# Altium schematic sheet power_board.SchDoc
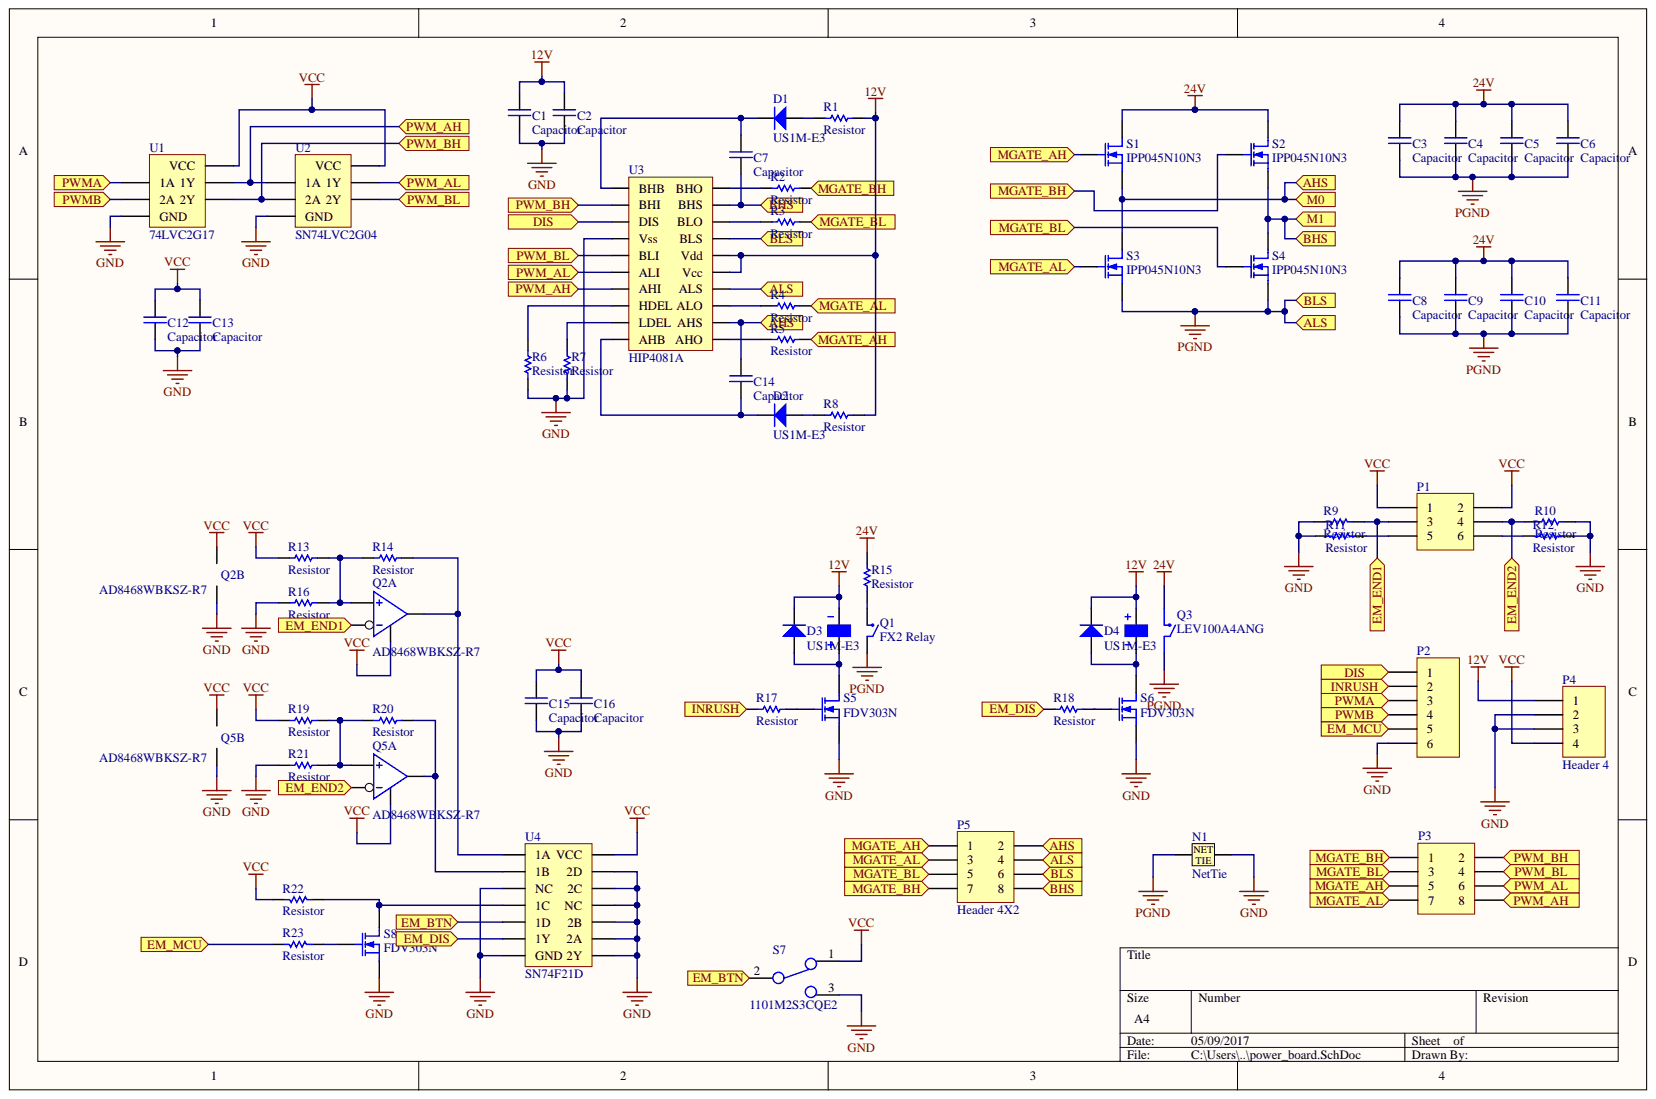
[diagram p0: power_board.SchDoc]

## Parts
C1  Capacitor  [0805]
C2  Capacitor  [0805]
C3  Capacitor  [150 CRZ]
C4  Capacitor  [150 CRZ]
C5  Capacitor  [150 CRZ]
C6  Capacitor  [150 CRZ]
C7  Capacitor  [0805]
C8  Capacitor  [0805]
C9  Capacitor  [0805]
C10  Capacitor  [0805]
C11  Capacitor  [0805]
C12  Capacitor  [0805]
C13  Capacitor  [0805]
C14  Capacitor  [0805]
C15  Capacitor  [0805]
C16  Capacitor  [0805]
D1  US1M-E3  [DO-214AC]
D2  US1M-E3  [DO-214AC]
D3  US1M-E3  [DO-214AC]
D4  US1M-E3  [DO-214AC]
N1  NetTie  [NetTie]
P1  (no value)  [HDR2X3]
P2  (no value)  [HDR1X6]
P3  (no value)  [HDR2X4]
P4  Header 4  [HDR1X4]
P5  Header 4X2  [HDR2X4]
Q1  FX2 Relay  [G6BK]
Q2  AD8468WBKSZ-R7  [SC70]  (parts A,B)
Q3  LEV100A4ANG  [HDR1X2]
Q5  AD8468WBKSZ-R7  [SC70]  (parts A,B)
R1  Resistor  [0805]
R2  Resistor  [0805]
R3  Resistor  [0805]
R4  Resistor  [0805]
R5  Resistor  [0805]
R6  Resistor  [0805]
R7  Resistor  [0805]
R8  Resistor  [0805]
R9  Resistor  [0805]
R10  Resistor  [0805]
R11  Resistor  [0805]
R12  Resistor  [0805]
R13  Resistor  [0805]
R14  Resistor  [0805]
R15  Resistor  [0805]
R16  Resistor  [0805]
R17  Resistor  [0805]
R18  Resistor  [0805]
R19  Resistor  [0805]
R20  Resistor  [0805]
R21  Resistor  [0805]
R22  Resistor  [0805]
R23  Resistor  [0805]
S1  IPP045N10N3  [TO-220 Flat]
S2  IPP045N10N3  [TO-220 Flat]
S3  IPP045N10N3  [TO-220 Flat]
S4  IPP045N10N3  [TO-220 Flat]
S5  FDV303N  [SOT-23B]
S6  FDV303N  [SOT-23B]
S7  1101M2S3CQE2  [1101M2S3CQE2_FP]
S8  FDV303N  [SOT-23B]
U1  74LVC2G17  [SOT-363]
U2  SN74LVC2G04  [SOT-363]
U3  HIP4081A  [SOIC-20 WB]
U4  SN74F21D  [SOIC-14]

## Ports
AHS, ALS, BHS, BLS, DIS, EM_BTN, EM_DIS, EM_END1, EM_END2, EM_MCU, INRUSH, M0, M1, MGATE_AH, MGATE_AL, MGATE_BH, MGATE_BL, PWM_AH, PWM_AL, PWM_BH, PWM_BL, PWMA, PWMB

## Nets
12V: C1.2 C2.2 D3.2 D4.2 P4.1 Q1.1 Q3.1 R1.2 R8.2 U3.15(Vcc) U3.16(Vdd)
24V: C3.2 C4.2 C5.2 C6.2 C8.2 C9.2 C10.2 C11.2 R15.2 S1.2 S2.2
GND: C1.1 C2.1 C12.1 C13.1 C15.1 C16.1 N1.1 P2.6 P4.2 P4.3 Q2.2 Q5.2 R6.1 R7.1 R9.2 R10.2 R11.2 R12.2 R16.2 R21.2 S5.2 S6.2 S7.3 S8.2 U1.2(GND) U2.2(GND) U3.4(Vss) U4.3(NC) U4.7(GND) U4.8(2Y) U4.9(2A) U4.10(2B) U4.11(NC) U4.13(2D)
VCC: C12.2 C13.2 C15.2 C16.2 P1.1 P1.2 P4.4 Q2.5 Q2.6 Q5.5 Q5.6 R13.2 R19.2 R22.1 S7.1 U1.5(VCC) U2.5(VCC) U4.14(VCC)
AHS: C14.2 P5.2 S1.3 S3.2 U3.12(AHS) ; port AHS, port M0
BHS: C7.1 P5.8 S2.3 S4.2 U3.19(BHS) ; port BHS, port M1
DIS: P2.1 U3.3(DIS) ; port DIS
EM_BTN: S7.2 U4.5(1D) ; port EM_BTN
EM_DIS: R18.2 U4.6(1Y) ; port EM_DIS
EM_END1: P1.3 Q2.4 R9.1 ; port EM_END1
EM_END2: P1.4 Q5.4 R10.1 ; port EM_END2
EM_MCU: P2.5 R23.2 ; port EM_MCU
INRUSH: P2.2 R17.2 ; port INRUSH
MGATE_AH: P3.5 P5.1 R5.2 S1.1 ; port MGATE_AH
MGATE_AL: P3.7 P5.3 R4.2 S3.1 ; port MGATE_AL
MGATE_BH: P3.1 P5.7 R2.2 S2.1 ; port MGATE_BH
MGATE_BL: P3.3 P5.5 R3.2 S4.1 ; port MGATE_BL
PGND: C3.1 C4.1 C5.1 C6.1 C8.1 C9.1 C10.1 C11.1 N1.2 P5.4 P5.6 Q1.3 S3.3 S4.3 U3.14(ALS) U3.17(BLS) ; port ALS, port BLS
PWM_AH: P3.8 U1.6(1Y) U2.1(1A) U3.7(AHI) ; port PWM_AH
PWM_AL: P3.6 U2.6(1Y) U3.6(ALI) ; port PWM_AL
PWM_BH: P3.2 U1.4(2Y) U2.3(2A) U3.2(BHI) ; port PWM_BH
PWM_BL: P3.4 U2.4(2Y) U3.5(BLI) ; port PWM_BL
PWMA: P2.3 U1.1(1A) ; port PWMA
PWMB: P2.4 U1.3(2A) ; port PWMB
NetC7_2: C7.2 D1.2 U3.1(BHB)
NetC14_1: C14.1 D2.2 U3.10(AHB)
NetD1_1: D1.1 R1.1
NetD2_1: D2.1 R8.1
NetD3_1: D3.1 Q1.8 S5.3
NetD4_1: D4.1 Q3.2 S6.3
NetP1_5: P1.5 R11.1
NetP1_6: P1.6 R12.1
NetQ1_4: Q1.4 R15.1
NetQ2_1: Q2.1 R14.2 U4.1(1A)
NetQ2_3: Q2.3 R13.1 R14.1 R16.1
NetQ5_1: Q5.1 R20.2 U4.2(1B)
NetQ5_3: Q5.3 R19.1 R20.1 R21.1
NetR2_1: R2.1 U3.20(BHO)
NetR3_1: R3.1 U3.18(BLO)
NetR4_1: R4.1 U3.13(ALO)
NetR5_1: R5.1 U3.11(AHO)
NetR6_2: R6.2 U3.8(HDEL)
NetR7_2: R7.2 U3.9(LDEL)
NetR17_1: R17.1 S5.1
NetR18_1: R18.1 S6.1
NetR22_2: R22.2 S8.3 U4.4(1C)
NetR23_1: R23.1 S8.1

## Library data of the parts
P1 = Header 3X2: Header, 3-Pin, Dual row; Component Kind: Standard; LatestRevisionDate: 17-Jul-2002; LatestRevisionNote: Re-released for DXP Platform.; Library Name: Miscellaneous Connectors.IntLib; Signal Integrity: Connector; Publisher: Altium Limited
P2 = Header 6: Header, 6-Pin; Component Kind: Standard; LatestRevisionDate: 17-Jul-2002; LatestRevisionNote: Re-released for DXP Platform.; Library Name: Miscellaneous Connectors.IntLib; Signal Integrity: Connector; Publisher: Altium Limited
P3 P5 = Header 4X2: Header, 4-Pin, Dual row; Component Kind: Standard; LatestRevisionDate: 17-Jul-2002; LatestRevisionNote: Re-released for DXP Platform.; Library Name: Miscellaneous Connectors.IntLib; Signal Integrity: Connector; Publisher: Altium Limited
P4 = Header 4: Header, 4-Pin; Component Kind: Standard; LatestRevisionDate: 17-Jul-2002; LatestRevisionNote: Re-released for DXP Platform.; Library Name: Miscellaneous Connectors.IntLib; Signal Integrity: Connector; Publisher: Altium Limited
U1 = 74LVC2G17: 74LVC2G17 Dual non-inverting Schmitt-trigger with 5v tolerant input; Component Kind: Standard; Library Name: sdu_embedded.SchLib
U2 = SN74LVC2G04: SN74LVC2G04 Dual inverter gate; Component Kind: Standard; Library Name: sdu_embedded.SchLib
U4 = SN74F21D: Dual 4-Input AND Gate; Component Kind: Standard; Library Name: sdu_embedded.SchLib
C1-C16 = Capacitor: Component Kind: Standard; Library Name: sdu_embedded.SchLib
D1-D4 = US1M-E3: Component Kind: Standard; Library Name: sdu_embedded.SchLib
N1 = NetTie: Component Kind: Net Tie; Library Name: sdu_embedded.SchLib
Q1 = FX2 Relay: Component Kind: Standard; Library Name: sdu_embedded.SchLib
Q2 Q5 = AD8468WBKSZ-R7: Component Kind: Standard; Library Name: sdu_embedded.SchLib
Q3 = LEV100A4ANG: Component Kind: Standard; Library Name: sdu_embedded.SchLib
R1-R23 = Resistor: Component Kind: Standard; Library Name: sdu_embedded.SchLib
S1-S4 = IPP045N10N3: Component Kind: Standard; Library Name: sdu_embedded.SchLib
S5 S6 S8 = FDV303N: Component Kind: Standard; Library Name: sdu_embedded.SchLib
S7 = 1101M2S3CQE2: Component Kind: Standard; Library Name: sdu_embedded.SchLib
U3 = HIP4081A: Component Kind: Standard; Library Name: sdu_embedded.SchLib
